# Altium schematic sheet PD-EC_EXT.SchDoc
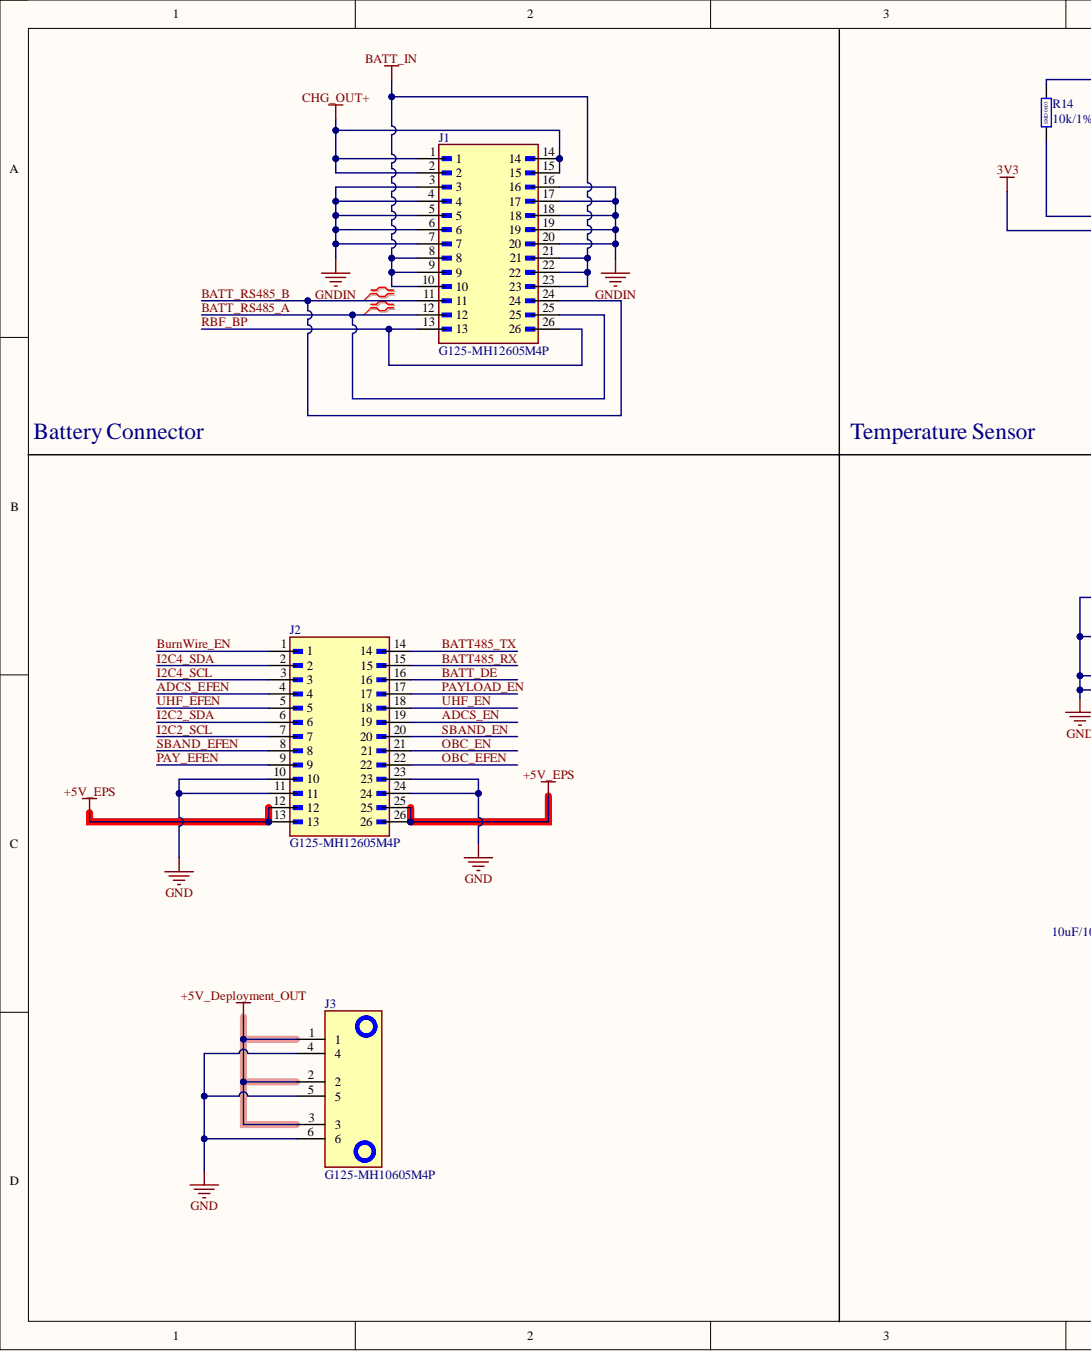
[diagram p0: PD-EC_EXT.SchDoc, left]
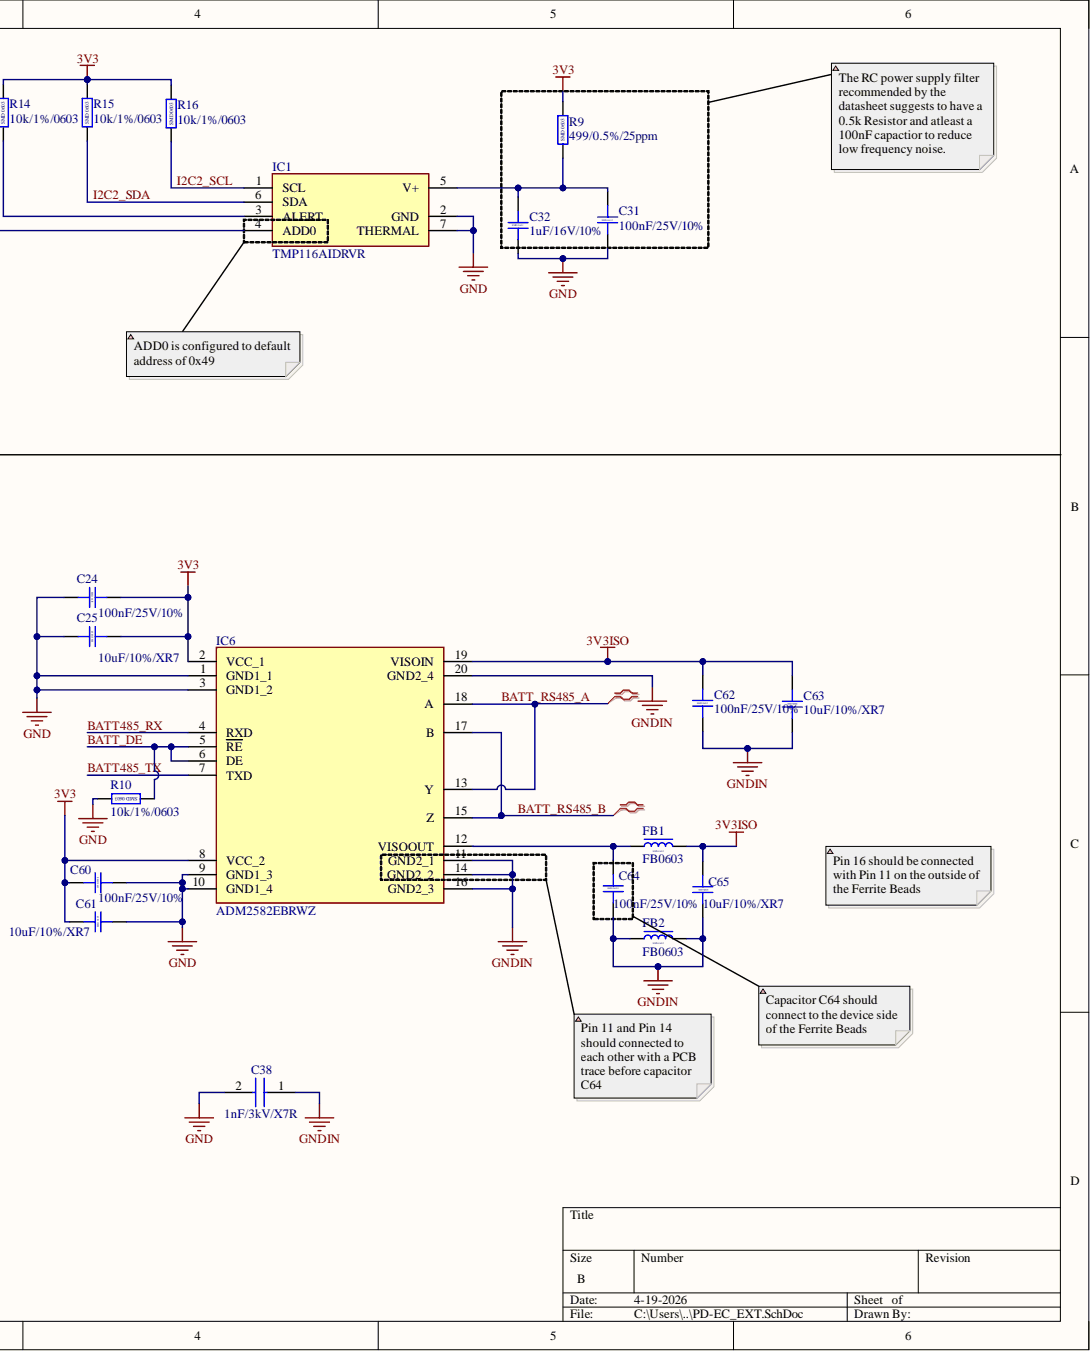
[diagram p1: PD-EC_EXT.SchDoc, right]

## Parts
C24  100nF/25V/10%  [CAP_0603]
C25  10uF/10%/XR7  [CAP_0805]
C31  100nF/25V/10%  [CAP_0603]
C32  1uF/16V/10%  [CAP_0603]
C38  1nF/3kV/X7R  [CAPC4532X270N]
C60  100nF/25V/10%  [CAP_0603]
C61  10uF/10%/XR7  [CAP_0805]
C62  100nF/25V/10%  [CAP_0603]
C63  10uF/10%/XR7  [CAP_0805]
C64  100nF/25V/10%  [CAP_0603]
C65  10uF/10%/XR7  [CAP_0805]
FB1  FB0603  [FB_0603]
FB2  FB0603  [FB_0603]
IC1  TMP116AIDRVR  [SON65P200X200X80-7N]
IC6  ADM2582EBRWZ  [SOIC127P1032X265-20N]
J1  G125-MH12605M4P  [HARWIN_G125-MH12605M4P]
J2  G125-MH12605M4P  [HARWIN_G125-MH12605M4P]
J3  G125-MH10605M4P  [G125-MH10605M4P_1]
R9  499/0.5%/25ppm  [RES_0603]
R10  10k/1%/0603  [RES_0603]
R14  10k/1%/0603  [RES_0603]
R15  10k/1%/0603  [RES_0603]
R16  10k/1%/0603  [RES_0603]

## Nets
3V3: C24.1 C25.2 C60.2 C61.1 IC1.4(ADD0) IC6.2(VCC_1) IC6.8(VCC_2) R9.2 R14.2 R15.2 R16.2
3V3ISO: C62.1 C63.2 C65.2 FB1.2 IC6.19(VISOIN)
+5V_Deployment_OUT: J3.1 J3.2 J3.3
+5V_EPS: J2.12 J2.13 J2.25 J2.26
BATT_IN: J1.8 J1.9 J1.10 J1.21 J1.22 J1.23
CHG_OUT+: J1.1 J1.2 J1.14 J1.15
GND: C24.2 C25.1 C31.2 C32.2 C38.2 C60.1 C61.2 IC1.2(GND) IC1.7(THERMAL) IC6.1(GND1_1) IC6.3(GND1_2) IC6.9(GND1_3) IC6.10(GND1_4) J2.10 J2.11 J2.23 J2.24 J3.4 J3.5 J3.6 R10.2
GNDIN: C38.1 C62.2 C63.1 C64.2 C65.1 FB2.1 FB2.2 IC6.11(GND2_1) IC6.14(GND2_2) IC6.16(GND2_3) IC6.20(GND2_4) J1.3 J1.4 J1.5 J1.6 J1.7 J1.16 J1.17 J1.18 J1.19 J1.20
ADCS_EFEN: J2.4
ADCS_EN: J2.19
BATT485_RX: IC6.4(RXD) J2.15
BATT485_TX: IC6.7(TXD) J2.14
BATT_DE: IC6.5(RE) IC6.6(DE) J2.16 R10.1
BATT_RS485_A: IC6.13(Y) IC6.18(A) J1.12 J1.25
BATT_RS485_B: IC6.15(Z) IC6.17(B) J1.11 J1.24
BurnWire_EN: J2.1
I2C2_SCL: IC1.1(SCL) J2.7 R16.1
I2C2_SDA: IC1.6(SDA) J2.6 R15.1
I2C4_SCL: J2.3
I2C4_SDA: J2.2
OBC_EFEN: J2.22
OBC_EN: J2.21
PAY_EFEN: J2.9
PAYLOAD_EN: J2.17
RBF_BP: J1.13 J1.26
SBAND_EFEN: J2.8
SBAND_EN: J2.20
UHF_EFEN: J2.5
UHF_EN: J2.18
NetC31_1: C31.1 C32.1 IC1.5(V+) R9.1
NetC64_1: C64.1 FB1.1 IC6.12(VISOOUT)
NetIC1_3: IC1.3(ALERT) R14.1

## Library data of the parts
C24 C31 C32 C60 C62 C64 = Capacitor-SMD-0603: C0603C104K3RACTU; Component Kind: Standard; Library Name: STeRG-Passives-Standard.SchLib
C25 C61 C63 C65 = Capacitor-SMD-0805: Capacitor SMD 0805 RATED; Component Kind: Standard; Library Name: STeRG-Passives-Standard.SchLib
C38 = HV1812Y102KXHARHV: Capacitor; Manufacturer_Name: Vishay; Arrow Part Number: HV1812Y102KXHARHV; Arrow Price/Stock: https://www.arrow.com/en/products/hv1812y102kxharhv/vishay; Component Kind: Standard; Datasheet Link: https://www.arrow.com/en/products/hv1812y102kxharhv/vishay; Height: 2.7mm; Manufacturer_Part_Number: HV1812Y102KXHARHV; Mouser Part Number: 77-HV1812Y102KXHARHV; Mouser Price/Stock: https://www.mouser.co.uk/ProductDetail/Vishay-Vitramon/HV1812Y102KXHARHV?qs=Ia%2F%252BjdTn2m7ayMfAHVISMQ%3D%3D
FB1 FB2 = FB_0603: Ferrite Bead SMD 0603; Component Kind: Standard; Library Name: STeRG-Passives-Standard.SchLib
IC1 = TMP116AIDRVR: Integrated Circuit; Manufacturer_Name: Texas Instruments; Arrow Part Number: TMP116AIDRVR; Arrow Price/Stock: https://www.arrow.com/en/products/tmp116aidrvr/texas-instruments?utm_currency=USD&region=nac; Component Kind: Standard; Datasheet Link: http://www.ti.com/lit/gpn/tmp116; Height: 0.8mm; Manufacturer_Part_Number: TMP116AIDRVR; Mouser Part Number: 595-TMP116AIDRVR; Mouser Price/Stock: https://www.mouser.co.uk/ProductDetail/Texas-Instruments/TMP116AIDRVR?qs=gt1LBUVyoHkC4iqK5Vip8g%3D%3D; Library Name: STeRG-ICs-Standard.SchLib
IC6 = ADM2582EBRWZ: Integrated Circuit; Manufacturer_Name: Analog Devices; Arrow Part Number: ADM2582EBRWZ; Arrow Price/Stock: https://www.arrow.com/en/products/adm2582ebrwz/analog-devices?utm_currency=USD&region=nac; Component Kind: Standard; Datasheet Link: http://www.analog.com/static/imported-files/data_sheets/ADM2582E_2587E.pdf; Height: 2.65mm; Manufacturer_Part_Number: ADM2582EBRWZ; Mouser Part Number: 584-ADM2582EBRWZ; Mouser Price/Stock: https://www.mouser.co.uk/ProductDetail/Analog-Devices/ADM2582EBRWZ?qs=BpaRKvA4VqEUmXw45IunQg%3D%3D; Library Name: STeRG-ICs-Standard.SchLib
J3 = G125-MH10605M4P: Connector; Manufacturer_Name: Harwin; Component Kind: Standard; Datasheet Link: https://content.harwin.com/m/667f1be9427fb768/original/DRG-02921-Technical-Drawing-Datasheet-G125-MH1XX05M4P-pdf.pdf; Height: 5.3mm; Manufacturer_Part_Number: G125-MH10605M4P; Mouser Part Number: 855-G125MH1065M4PM4P; Mouser Price/Stock: https://www.mouser.co.uk/ProductDetail/Harwin/G125-MH10605M4P?qs=vHuUswq2%252BswF07IXFR1W6A%3D%3D; Library Name: STeRG-Passives-Standard.SchLib
R9 = Resistor-SMD-0603: RR0816P-4990-D-68A; Component Kind: Standard; Library Name: STeRG-Passives-Standard.SchLib
R10 R14-R16 = Resistor-SMD-0603: CRCW060310K0FKEA; Component Kind: Standard; Library Name: STeRG-Passives-Standard.SchLib
J1 J2 = G125-MH12605M4P: Availability: Unavailable; Component Kind: Standard; Library Name: G125-MH12605M4P.SchLib; MP: G125-MH12605M4P; Package: None; Price: None
